# Altium schematic sheet PetAutomaticFeeder.SchDoc
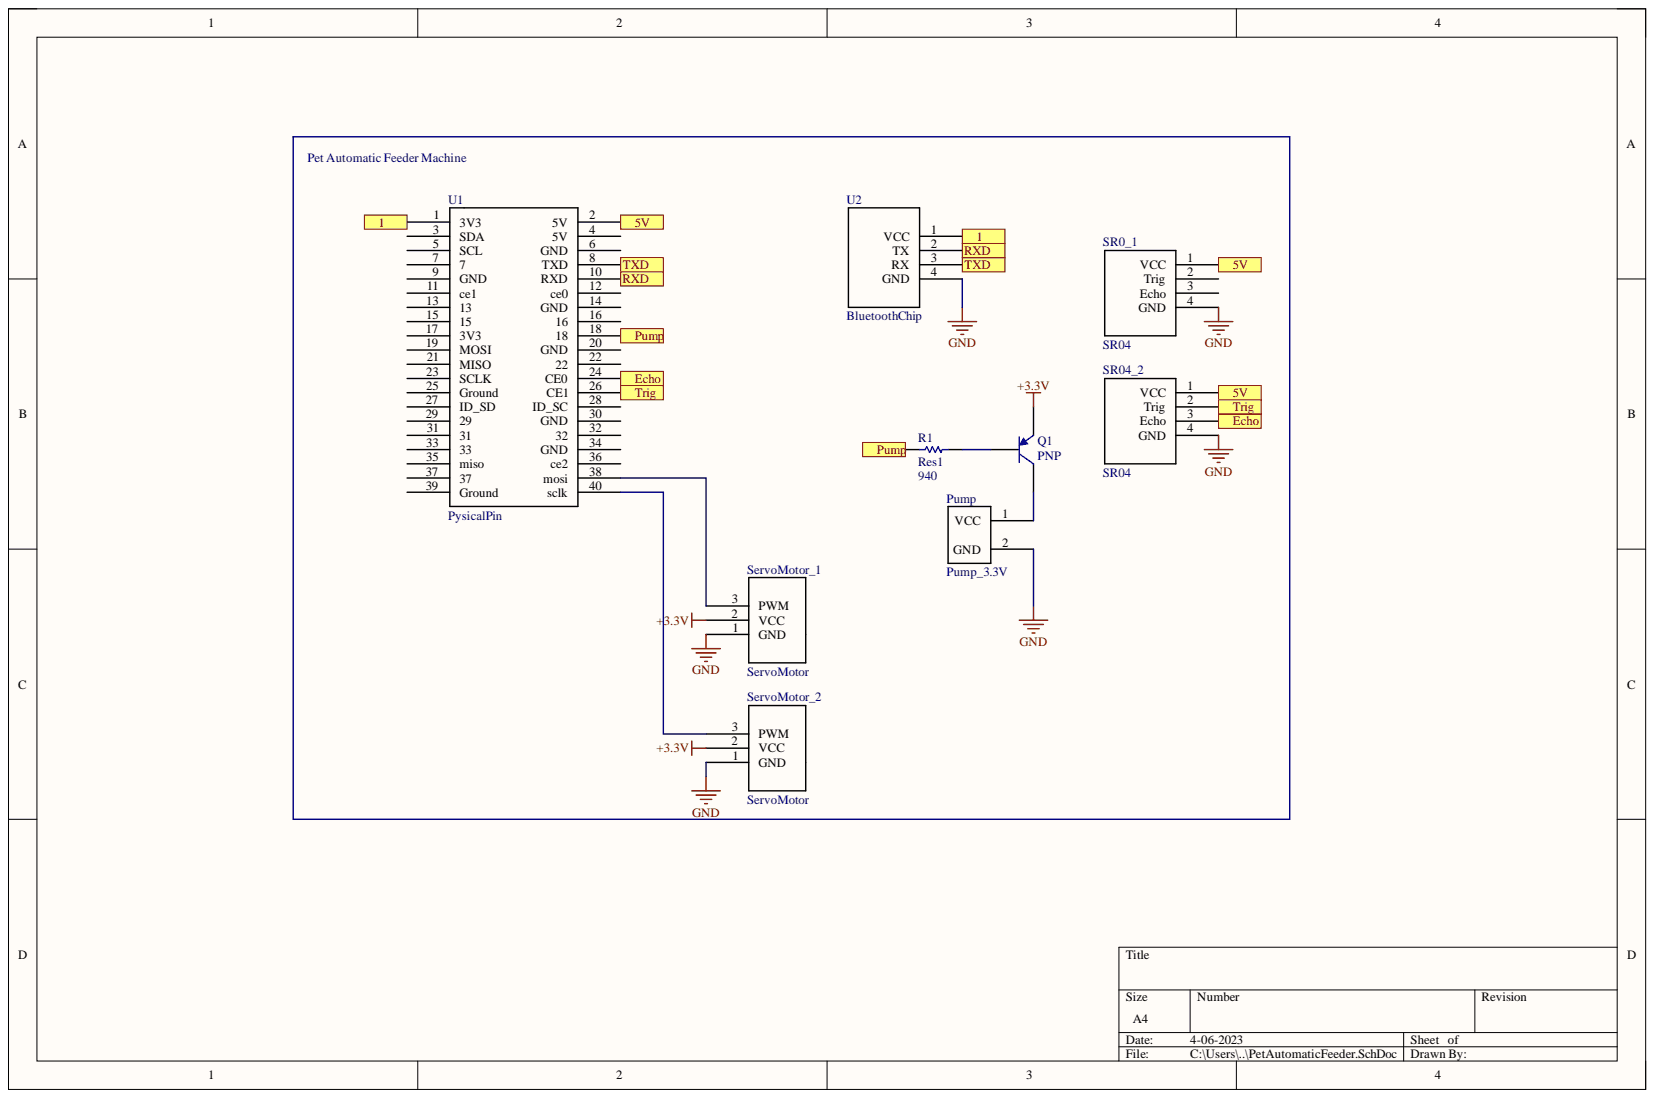
[diagram p0: PetAutomaticFeeder.SchDoc]

## Parts
Pump  Pump_3.3V
Q1  PNP  [SOT-23B_N]
R1  Res1  value 940  [AXIAL-0.3]
ServoMotor_1  ServoMotor
ServoMotor_2  ServoMotor
SR0_1  SR04
SR04_2  SR04
U1  PysicalPin
U2  BluetoothChip

## Ports
1, 5V, Echo, Pump, RXD, Trig, TXD

## Nets
+3.3V: Q1.3 ServoMotor_1.2 ServoMotor_2.2
GND: Pump.2 ServoMotor_1.1 ServoMotor_2.1 SR0_1.4(GND) SR04_2.4(GND) U2.4(GND)
1: U1.1(3V3) U2.1(VCC) ; port 1
5V: SR0_1.1(VCC) SR04_2.1(VCC) U1.2(5V) ; port 5V
Echo: SR04_2.3(Echo) U1.24(CE0) ; port Echo
Pump: R1.1 U1.18 ; port Pump
RXD: U1.10(RXD) U2.2(TX) ; port RXD
Trig: SR04_2.2(Trig) U1.26(CE1) ; port Trig
TXD: U1.8(TXD) U2.3(RX) ; port TXD
NetPump_1: Pump.1 Q1.1
NetQ1_2: Q1.2 R1.2
NetServoMotor_1_3: ServoMotor_1.3 U1.38(mosi)
NetServoMotor_2_3: ServoMotor_2.3 U1.40(sclk)

Not connected: SR0_1 2(Trig) 3(Echo); U1 3(SDA) 4(5V) 5(SCL) 6(GND) 7 9(GND) 11(ce1) 12(ce0) 13 14(GND) 15 16 17(3V3) 19(MOSI) 20(GND) 21(MISO) 22 23(SCLK) 25(Ground) 27(ID_SD) 28(ID_SC) 29 30(GND) 31 32 33 34(GND) 35(miso) 36(ce2) 37 39(Ground)

## Library data of the parts
Pump = Pump: Component Kind: Standard; Library Name: Schlib1.SchLib
Q1 = PNP: PNP Bipolar Transistor; Component Kind: Standard; LatestRevisionDate: 29-May-2009; LatestRevisionNote: IPC-7351 Footprint Added.; Library Name: Miscellaneous Devices.IntLib; PackageDescription: 3-Pin SOT-23 Package 0.95 mm Pitch, 2.4 mm Lead Span; PackageReference: SOT-23B; PackageVersion: Aug-1999; Published: 8-Jun-2000; Signal Integrity: PNP; Simulation: PNP; Publisher: Altium Limited
R1 = Res1: Resistor; Component Kind: Standard; LatestRevisionDate: 17-Jul-2002; LatestRevisionNote: Re-released for DXP Platform.; Library Name: Miscellaneous Devices.IntLib; PackageDescription: Axial Device, Thru-Hole; 2 Leads; 0.3 in Pin Spacing; PackageReference: AXIAL-0.3; Published: 8-Jun-2000; Signal Integrity: Res; Simulation: RESISTOR; Publisher: Altium Limited
U1 = RespberryPi: Component Kind: Standard; Library Name: Schlib1.SchLib
ServoMotor_1 ServoMotor_2 = ServoMotor: Component Kind: Standard; Library Name: Schlib1.SchLib
SR0_1 SR04_2 = SR04: Component Kind: Standard; Library Name: Schlib1.SchLib
U2 = BluetoothChip: Component Kind: Standard; Library Name: Schlib1.SchLib
